# Altium schematic sheet POWER_SUPPLY.SchDoc
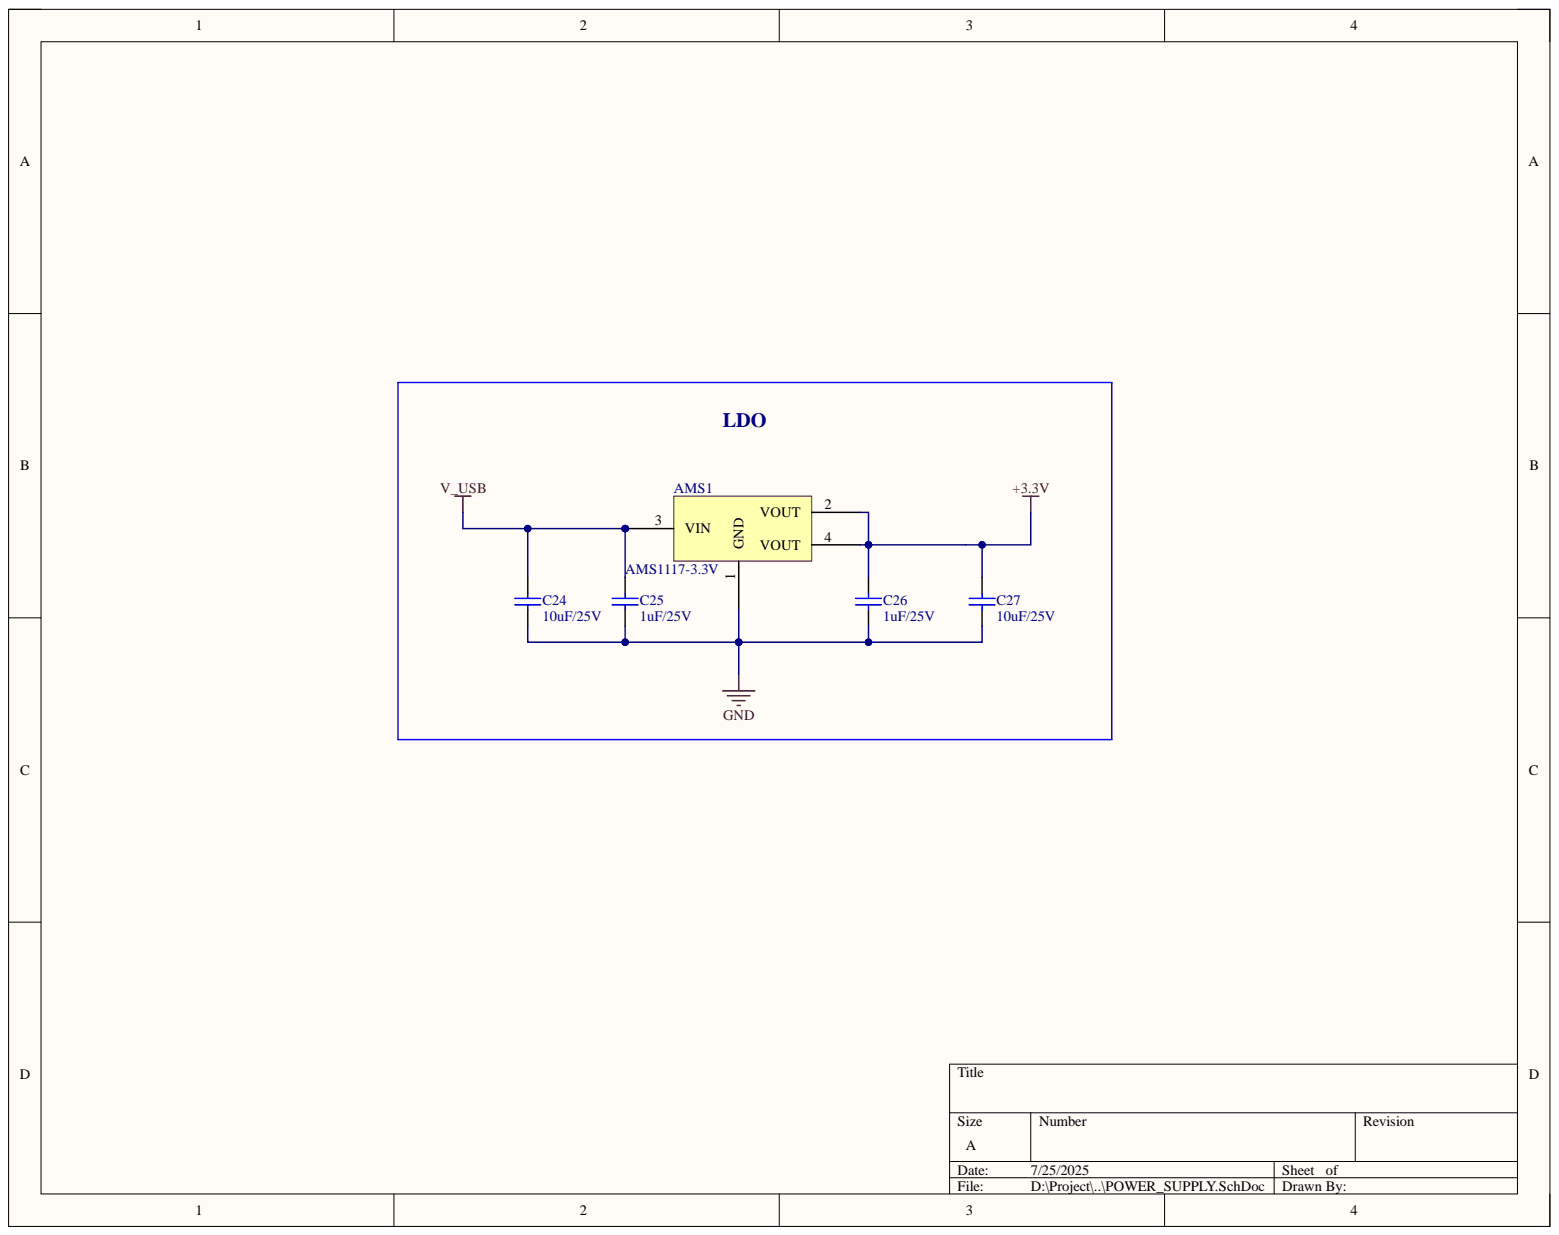
[diagram p0: POWER_SUPPLY.SchDoc]

## Parts
AMS1  AMS1117-3.3V  [SOT223]
C24  value 10uF/25V  [CAP-SM-0805]  (hidden comment: Cap Non-Pol SMD)
C25  value 1uF/25V  [CAP-SM-0805]  (hidden comment: Cap Non-Pol SMD)
C26  value 1uF/25V  [CAP-SM-0805]  (hidden comment: Cap Non-Pol SMD)
C27  value 10uF/25V  [CAP-SM-0805]  (hidden comment: Cap Non-Pol SMD)

## Nets
+3.3V: AMS1.2(VOUT) AMS1.4(VOUT) C26.2 C27.2
GND: AMS1.1(GND) C24.1 C25.1 C26.1 C27.1
V_USB: AMS1.3(VIN) C24.2 C25.2

## Library data of the parts
AMS1 = AMS1117 SMD: Dán; Don_Vi: Con; Ma_Hang: MH000596; Value: AMS1117-3.3V SOT223; Chung_Loai: Dán; Component Kind: Standard; Library Name: Altium_Lib_by_KhueNguyenCreator.IntLib
C24-C27 = Cap Non-Pol SMD: Capacitor; Component Kind: Standard; Library Name: PIF_Library.IntLib
